# Altium schematic sheet Accelerometer.SchDoc(Accelerometer)
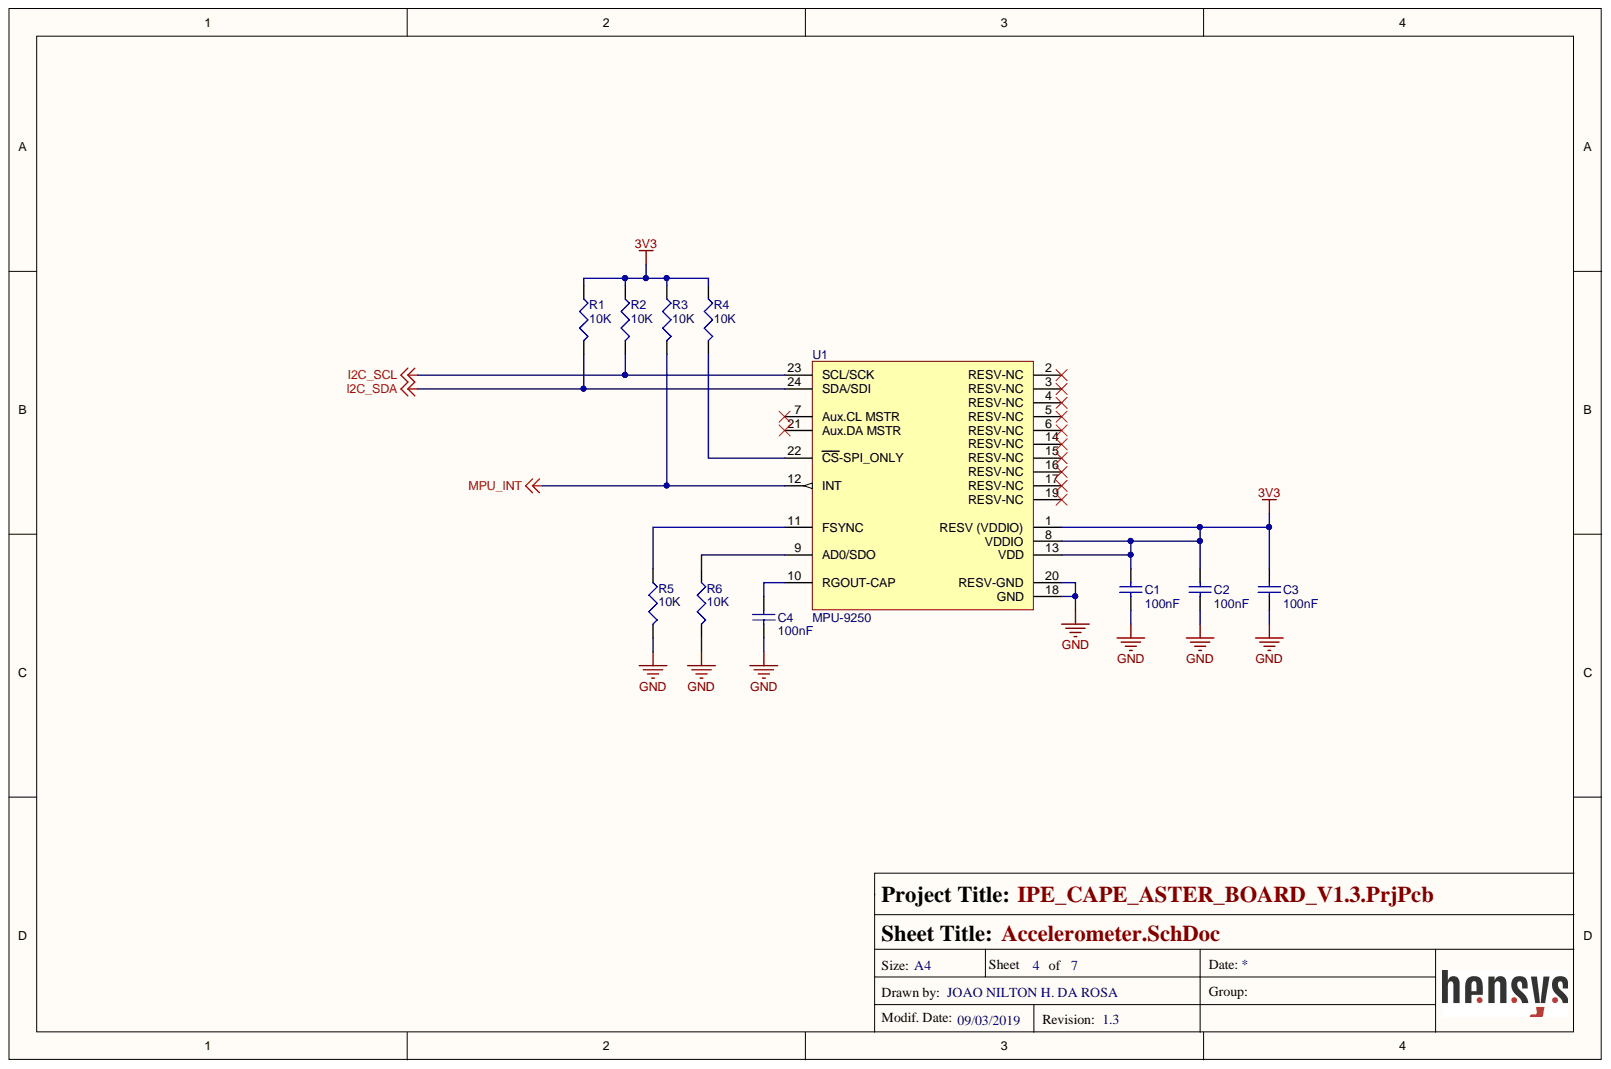
[diagram p0: Accelerometer.SchDoc]

## Parts
C1  100nF  [0402 cap]
C2  100nF  [0402 cap]
C3  100nF  [0402 cap]
C4  100nF  [0402 cap]
R1  10K  [0402 res]
R2  10K  [0402 res]
R3  10K  [0402 res]
R4  10K  [0402 res]
R5  10K  [0402 res]
R6  10K  [0402 res]
U1  MPU-9250  [QFN24 3x3x1]

## Nets
3V3: C1.2 C2.2 C3.2 R1.1 R2.1 R3.1 R4.1 U1.1(RESV_(VDDIO)) U1.8(VDDIO) U1.13(VDD)
GND: C1.1 C2.1 C3.1 C4.1 R5.2 R6.2 U1.18(GND) U1.20(RESV-GND)
I2C_SCL: R2.2 U1.23(SCL/SCK)
I2C_SDA: R1.2 U1.24(SDA/SDI)
MPU_INT: R3.2 U1.12(INT)
NetC4_2: C4.2 U1.10(RGOUT-CAP)
NetR4_2: R4.2 U1.22(CS-SPI_ONLY)
NetR5_1: R5.1 U1.11(FSYNC)
NetR6_1: R6.1 U1.9(AD0/SDO)

Not connected: U1 2(RESV-NC) 3(RESV-NC) 4(RESV-NC) 5(RESV-NC) 6(RESV-NC) 7(Aux.CL_MSTR) 14(RESV-NC) 15(RESV-NC) 16(RESV-NC) 17(RESV-NC) 19(RESV-NC) 21(Aux.DA_MSTR)

## Library data of the parts
C1-C4 = Cap Ceram 0402: CAP CER 0.1UF 16V X7R 0402; Manufacturer Part Number: GCM155R71C104KA55D; Manufacturer: Murata Electronics North America; Tolerance: ±10%; Voltage - Rated: 16V; Thickness (Max): 0.022" (0.55mm); Temperature Coefficient: X7R; Supplier Part Number 1: 490-4759-1-ND; Supplier 1: Digi-Key; Stock 1: 918618; Size / Dimension: 0.039" L x 0.020" W (1.00mm x 0.50mm); RoHS: RoHS Compliant; Ratings: AEC-Q200; Pricing 1: 1=0.1, 10=0.039, 100=0.0174, 500=0.01244, 1000=0.00977, 2500=0.00888, 5000=0.00817 (USD); Part Status: Active; Packaging: Cut Tape (CT); Package / Case: 0402 (1005 Metric); Operating Temperature: -55°C ~ 125°C; Mounting Type: Surface Mount, MLCC; Lead Style: -; Lead Spacing: -; Height - Seated (Max): -; Features: -; ComponentLink1URL: http://psearch.en.murata.com/capacitor/product/GCM155R71C104KA55#.pdf; ComponentLink1Description: http://psearch.en.murata.com/capacitor/product/GCM155R71C104KA55#.pdf; Component Kind: Standard; Category: Capacitors; Applications: Automotive; Library Name: Passivos.IntLib
R1-R6 = Resistor 0402: RES SMD 10K OHM 5% 1/16W 0402; Manufacturer Part Number: RC1005J103CS; Manufacturer: Samsung Electro-Mechanics; Temperature Coefficient: ±100ppm/°C; Power (Watts): 0.063W, 1/16W; Tolerance: ±5%; Supplier Part Number 1: 1276-4400-1-ND; Supplier Device Package: 0402; Supplier 1: Digi-Key; Stock 1: 523260; Size / Dimension: 0.039" L x 0.020" W (1.00mm x 0.50mm); RoHS: RoHS Compliant; Pricing 1: 1=0.1, 10=0.011, 100=0.0046, 1000=0.00207, 2500=0.0018, 5000=0.00148 (USD); Part Status: Active; Packaging: Cut Tape (CT); Package / Case: 0402 (1005 Metric); Operating Temperature: -55°C ~ 155°C; Height - Seated (Max): 0.016" (0.40mm); Features: Moisture Resistant; Failure Rate: -; Composition: Thick Film; ComponentLink1URL: http://www.samsungsem.com/kr/support/product-search/chip-resistor/RC1005J103CS.jsp; ComponentLink1Description: http://www.samsungsem.com/kr/support/product-search/chip-resistor/RC1005J103CS.jsp; Component Kind: Standard; Category: Resistors
  R1-R4: Library Name: Passivos.IntLib
  R5 R6: Library Name: IPE_CAPE_ASTER_BOARD_V1.0.IntLib
U1 = MPU-9250: IMU ACCEL/GYRO/MAG I2C/SPI 24QFN; Manufacturer: TDK InvenSense; Sensor Type: Accelerometer, Gyroscope, Magnetometer, 3 Axis; Output Type: I²C, SPI; Supplier Part Number 1: 1428-1019-1-ND; Supplier 1: Digi-Key; Stock 1: 82181; RoHS: RoHS Compliant; Pricing 1: 1=10.63, 5=9.322, 10=8.39, 25=7.458, 50=6.8986, 100=5.9664, 500=5.5935, 1000=5.2206 (USD); Part Status: Active; Operating Temperature: -40°C ~ 85°C (TA); Manufacturer Part Number: MPU-9250; Library Name: TDK  InvenSense IMU.IntLib; ComponentLink1URL: https://store.invensense.com/datasheets/invensense/MPU9250REV1.0.pdf; ComponentLink1Description: https://store.invensense.com/datasheets/invensense/MPU9250REV1.0.pdf; Component Kind: Standard; Category: Sensors, Transducers
